# Altium schematic sheet Motor.SchDoc
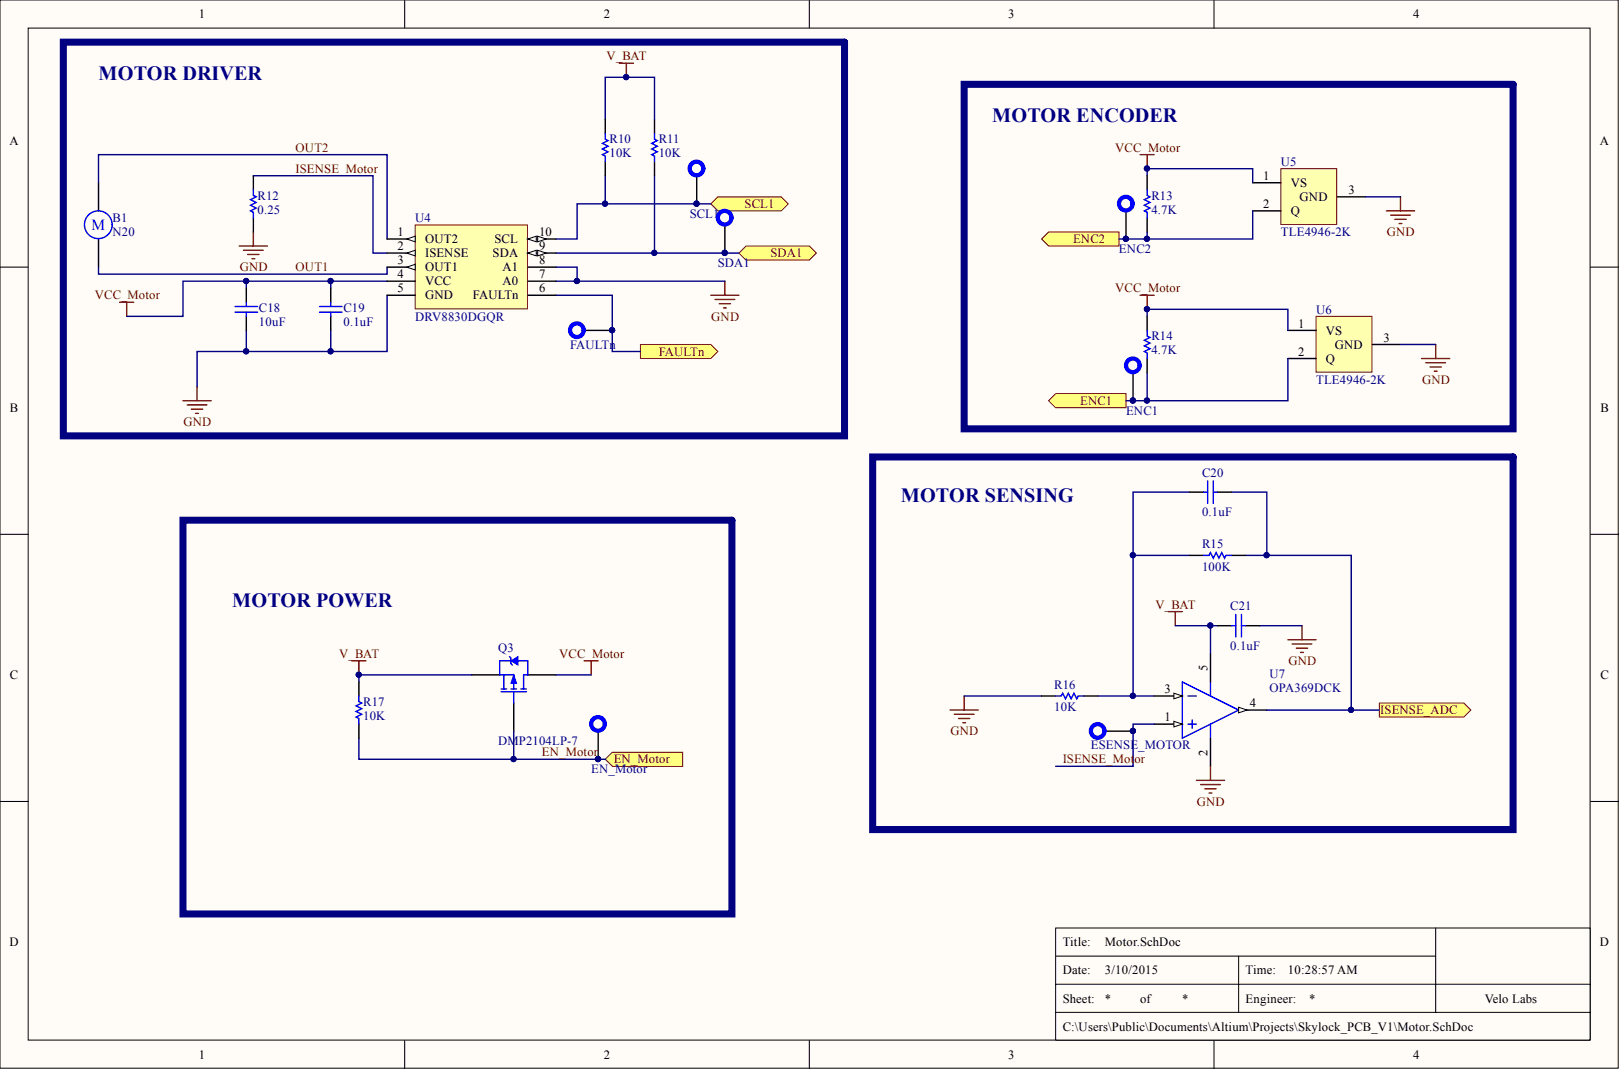
[diagram p0: Motor.SchDoc]

## Parts
B1  N20  [n20_motor]
C18  10uF  [C0603]
C19  0.1uF  [C0402]
C20  0.1uF  [C0402]
C21  0.1uF  [C0402]
EN_Motor  Test Point  [TP]  (value not drawn)  (no BOM)
ENC1  Test Point  [TP]  (value not drawn)  (no BOM)
ENC2  Test Point  [TP]  (value not drawn)  (no BOM)
ESENSE_MOTOR  Test Point  [TP]  (value not drawn)  (no BOM)
FAULTn  Test Point  [TP]  (value not drawn)  (no BOM)
Q3  DMP2104LP-7  [3-dfn]
R10  10K  [R0402]
R11  10K  [R0402]
R12  0.25  [R0805]
R13  4.7K  [R0402]
R14  4.7K  [R0402]
R15  100K  [R0402]
R16  10K  [R0402]
R17  10K  [R0402]
SCL1  Test Point  [TP]  (value not drawn)  (no BOM)
SDA1  Test Point  [TP]  (value not drawn)  (no BOM)
U4  DRV8830DGQR  [QFN50P300X300X80_HS-15N]
U5  TLE4946-2K  [SC59]
U6  TLE4946-2K  [SC59]
U7  OPA369DCK  [SC70-5]

## Ports
EN_MOTOR, ENC1, ENC2, FAULTN, ISENSE_ADC, SCL1, SDA1

## Nets
GND: C18.2 C19.2 C21.2 R12.2 R16.1 U4.0 U4.5(GND) U4.7(A0) U4.8(A1) U5.3 U6.3 U7.2
V_BAT: C21.1 Q3.2 R10.1 R11.1 R17.1 U7.5
VCC_MOTOR: C18.1 C19.1 Q3.3 R13.1 R14.1 U4.4(VCC) U5.1 U6.1
EN_MOTOR: EN_Motor.1 Q3.1 R17.2 ; port EN_MOTOR
ENC1: ENC1.1 R14.2 U6.2 ; port ENC1
ENC2: ENC2.1 R13.2 U5.2 ; port ENC2
ISENSE_ADC: C20.2 R15.2 U7.4 ; port ISENSE_ADC
ISENSE_MOTOR: ESENSE_MOTOR.1 R12.1 U4.2(ISENSE) U7.1
OUT1: B1.2 U4.3(OUT1)
OUT2: B1.1 U4.1(OUT2)
SCL1: R10.2 SCL1.1 U4.10(SCL) ; port SCL1
SDA1: R11.2 SDA1.1 U4.9(SDA) ; port SDA1
NetC20_1: C20.1 R15.1 R16.2 U7.3
NetFAULTn_1: FAULTn.1 U4.6(FAULTn) ; port FAULTN

## Library data of the parts
B1 = Motor: Motor, General Kind; Component Kind: Standard; LatestRevisionDate: 17-Jul-2002; LatestRevisionNote: Re-released for DXP Platform.; PackageDescription: Capacitor (Radial); 2 Leads; PackageReference: RB5-10.5; Published: 24-Mar-1999; Library Name: VL_Skylock_Nordic.SCHLIB; Publisher: Altium Limited
C18 = Cap Semi: Capacitor; Manufacturer: Taiyo Yuden; Manufacturer Part Number: JMK107ABJ106MAHT; Capacitance: 10µF; Temperature Coefficient: X5R; Tolerance: ±20%; Voltage - Rated: 6.3V; Applications: Automotive; Category: Capacitors; Component Kind: Standard; ComponentLink1Description: http://www.yuden.co.jp/productdata/catalog/en/mlcc01_hq_e.pdf; ComponentLink1URL: http://www.yuden.co.jp/productdata/catalog/en/mlcc01_hq_e.pdf; Features: -; Height - Seated (Max): -; LatestRevisionDate: 29-May-2009; LatestRevisionNote: IPC-7351 Footprint Added.; Lead Spacing: -; Lead Style: -; Mounting Type: Surface Mount, MLCC; Operating Temperature: -55°C ~ 85°C; Package / Case: 0603 (1608 Metric); PackageDescription: Chip Capacitor; PackageReference: C1206; Packaging: Cut Tape (CT); Pricing 1: 1=0.32, 10=0.21, 50=0.109, 100=0.084, 250=0.06036, 500=0.04306, 1000=0.0315 (USD); Published: 8-Jun-2000; Ratings: AEC-Q200; RoHS: RoHS Compliant; Signal Integrity: Cap Semi; Simulation: CAP; Size / Dimension: 0.063" L x 0.031" W (1.60mm x 0.80mm); Stock 1: 197603; Supplier 1: Digi-Key; Supplier Part Number 1: 587-3383-1-ND; Thickness (Max): 0.035" (0.90mm); Value: 10uF; Library Name: VL_Skylock_Nordic.SCHLIB; Publisher: Altium Limited
C19-C21 = Cap Semi: Capacitor; Manufacturer: Murata Electronics North America; Manufacturer Part Number: GRM155R61A104KA01D; Capacitance: 0.1µF; Temperature Coefficient: X5R; Tolerance: ±10%; Voltage - Rated: 10V; Applications: General Purpose; Category: Capacitors; Component Kind: Standard; ComponentLink1Description: http://www.murata.com/~/media/webrenewal/support/library/catalog/products/capacitor/mlcc/c02e.ashx; ComponentLink1URL: http://www.murata.com/~/media/webrenewal/support/library/catalog/products/capacitor/mlcc/c02e.ashx; Features: -; Height - Seated (Max): -; LatestRevisionDate: 29-May-2009; LatestRevisionNote: IPC-7351 Footprint Added.; Lead Spacing: -; Lead Style: -; Mounting Type: Surface Mount, MLCC; Operating Temperature: -55°C ~ 85°C; Package / Case: 0402 (1005 Metric); PackageDescription: Chip Capacitor; PackageReference: C1206; Packaging: Cut Tape (CT); Pricing 1: 1=0.1, 10=0.012, 50=0.0066, 100=0.0055, 250=0.00452, 500=0.00386, 1000=0.003, 5000=0.0025 (USD); Published: 8-Jun-2000; Ratings: -; RoHS: RoHS Compliant; Signal Integrity: Cap Semi; Simulation: CAP; Size / Dimension: 0.039" L x 0.020" W (1.00mm x 0.50mm); Stock 1: 7992964; Supplier 1: Digi-Key; Supplier Part Number 1: 490-1318-1-ND; Thickness (Max): 0.022" (0.55mm); Value: 0.1uF; Library Name: VL_Skylock_Nordic.SCHLIB; Publisher: Altium Limited
Q3 = DMP2104LP-7: MOSFET P-CH 20V 1.5A 3-DFN; Manufacturer: Diodes Inc; Current - Continuous Drain (Id) @ 25° C: 1.5A (Ta); FET Feature: Logic Level Gate; FET Type: MOSFET P-Channel, Metal Oxide; Input Capacitance (Ciss) @ Vds: 320pF @ 16V; Power - Max: 500mW; Rds On (Max) @ Id, Vgs: 150 mOhm @ 950mA, 4.5V; Vgs(th) (Max) @ Id: 1V @ 250µA; Category: Discrete Semiconductor Products; Component Kind: Standard; ComponentLink1Description: http://www.diodes.com/datasheets/ds31091.pdf; ComponentLink1URL: http://www.diodes.com/datasheets/ds31091.pdf; Description 1: MOSFET P-CH 20V 1.5A 3-DFN; Drain to Source Voltage (Vdss): 20V; Gate Charge (Qg) @ Vgs: -; LatestRevisionDate: 17-Jul-2002; LatestRevisionNote: Re-released for DXP Platform.; Manufacturer Part Number: DMP2104LP-7; Mounting Type: Surface Mount; Package / Case: 3-XDFN; PackageDescription: TO, Flat Index; 3 In-Line, Axial Leads; Body Dia. 4.6mm; Lead Dia. 0.5 mm (max); PackageReference: E3; PackageVersion: 1995/1996; Packaging: Digi-Reel®; Pricing 1: 1=0.44, 10=0.341, 25=0.288, 100=0.2347, 250=0.19436, 500=0.16056, 1000=0.12025 (USD); Published: 8-Jun-2000; RoHS: RoHS Compliant; Simulation: PMOS; Stock 1: 8333; Supplier 1: Digi-Key; Supplier Device Package: DFN1411-3; Supplier Part Number 1: DMP2104LPDIDKR-ND; Library Name: VL_Skylock_Nordic.SCHLIB; Publisher: Altium Limited
R10 R11 R16 R17 = Res: Resistor; Manufacturer: Panasonic Electronic Components; Manufacturer Part Number: ERJ-2RKF1002X; Power (Watts): 0.1W, 1/10W; Temperature Coefficient: ±100ppm/°C; Tolerance: ±1%; Category: Resistors; Component Kind: Standard; ComponentLink1Description: http://industrial.panasonic.com/www-cgi/jvcr13pz.cgi?E+PZ+3+AOA0002+ERJ2RKF1002X+7+WW; ComponentLink1URL: http://industrial.panasonic.com/www-cgi/jvcr13pz.cgi?E+PZ+3+AOA0002+ERJ2RKF1002X+7+WW; Composition: Thick Film; Features: Automotive AEC-Q200; Height: 0.016" (0.40mm); LatestRevisionDate: 17-Jul-2002; LatestRevisionNote: Re-released for DXP Platform.; Number of Terminations: 2; Operating Temperature: -55°C ~ 155°C; Package / Case: 0402 (1005 Metric); PackageDescription: Axial Device, Thru-Hole; 2 Leads; 0.3 in Pin Spacing; PackageReference: AXIAL-0.3; Packaging: Cut Tape (CT); Pricing 1: 1=0.1, 50=0.0196, 100=0.0149, 250=0.01104, 500=0.00816, 1000=0.00544, 5000=0.00383 (USD); Published: 8-Jun-2000; Resistance (Ohms): 10k; RoHS: RoHS Compliant; Signal Integrity: Res; Simulation: RESISTOR; Size / Dimension: 0.039" L x 0.020" W (1.00mm x 0.50mm); Stock 1: 8361710; Supplier 1: Digi-Key; Supplier Device Package: 0402; Supplier Part Number 1: P10.0KLCT-ND; Value: 10K; Library Name: VL_Skylock_Nordic.SCHLIB; Publisher: Altium Limited
R12 = Res: Resistor; Manufacturer: Stackpole Electronics Inc; Manufacturer Part Number: CSR0805FKR250; Power (Watts): 0.25W, 1/4W; Temperature Coefficient: ±200ppm/°C; Tolerance: ±1%; Category: Resistors; Component Kind: Standard; ComponentLink1Description: http://www.seielect.com/catalog/SEI-CSR_CSRN.pdf; ComponentLink1URL: http://www.seielect.com/catalog/SEI-CSR_CSRN.pdf; Composition: Thick Film; Features: Current Sense; Height: 0.026" (0.65mm); LatestRevisionDate: 17-Jul-2002; LatestRevisionNote: Re-released for DXP Platform.; Number of Terminations: 2; Operating Temperature: -55°C ~ 155°C; Package / Case: 0805 (2012 Metric); PackageDescription: Axial Device, Thru-Hole; 2 Leads; 0.3 in Pin Spacing; PackageReference: AXIAL-0.3; Packaging: Cut Tape (CT); Pricing 1: 1=0.56, 10=0.38, 50=0.23, 100=0.19, 250=0.15, 500=0.128 (USD); Published: 8-Jun-2000; Resistance (Ohms): 0.25; RoHS: RoHS Compliant; Signal Integrity: Res; Simulation: RESISTOR; Size / Dimension: 0.079" L x 0.049" W (2.00mm x 1.25mm); Stock 1: 4846; Supplier 1: Digi-Key; Supplier Device Package: 0805; Supplier Part Number 1: CSR0805FKR250CT-ND; Value: 0.25; Library Name: VL_Skylock_Nordic.SCHLIB; Publisher: Altium Limited
R13 R14 = Res: Resistor; Manufacturer: Panasonic Electronic Components; Manufacturer Part Number: ERJ-2GEJ472X; Power (Watts): 0.1W, 1/10W; Temperature Coefficient: ±200ppm/°C; Tolerance: ±5%; Category: Resistors; Component Kind: Standard; ComponentLink1Description: http://industrial.panasonic.com/www-cgi/jvcr13pz.cgi?E+PZ+3+AOA0001+ERJ2GEJ472X+7+WW; ComponentLink1URL: http://industrial.panasonic.com/www-cgi/jvcr13pz.cgi?E+PZ+3+AOA0001+ERJ2GEJ472X+7+WW; Composition: Thick Film; Features: Automotive AEC-Q200; Height: 0.016" (0.40mm); LatestRevisionDate: 17-Jul-2002; LatestRevisionNote: Re-released for DXP Platform.; Number of Terminations: 2; Operating Temperature: -55°C ~ 155°C; Package / Case: 0402 (1005 Metric); PackageDescription: Axial Device, Thru-Hole; 2 Leads; 0.3 in Pin Spacing; PackageReference: AXIAL-0.3; Packaging: Cut Tape (CT); Pricing 1: 1=0.1, 50=0.0138, 100=0.0105, 250=0.0078, 500=0.00576, 1000=0.00384, 5000=0.0027 (USD); Published: 8-Jun-2000; Resistance (Ohms): 4.7k; RoHS: RoHS Compliant; Signal Integrity: Res; Simulation: RESISTOR; Size / Dimension: 0.039" L x 0.020" W (1.00mm x 0.50mm); Stock 1: 3738322; Supplier 1: Digi-Key; Supplier Device Package: 0402; Supplier Part Number 1: P4.7KJCT-ND; Value: 4.7K; Library Name: VL_Skylock_Nordic.SCHLIB; Publisher: Altium Limited
R15 = Res: Resistor; Manufacturer: Panasonic Electronic Components; Manufacturer Part Number: ERJ-2GEJ104X; Power (Watts): 0.1W, 1/10W; Temperature Coefficient: ±200ppm/°C; Tolerance: ±5%; Category: Resistors; Component Kind: Standard; ComponentLink1Description: http://industrial.panasonic.com/www-cgi/jvcr13pz.cgi?E+PZ+3+AOA0001+ERJ2GEJ104X+7+WW; ComponentLink1URL: http://industrial.panasonic.com/www-cgi/jvcr13pz.cgi?E+PZ+3+AOA0001+ERJ2GEJ104X+7+WW; Composition: Thick Film; Features: Automotive AEC-Q200; Height: 0.016" (0.40mm); LatestRevisionDate: 17-Jul-2002; LatestRevisionNote: Re-released for DXP Platform.; Number of Terminations: 2; Operating Temperature: -55°C ~ 155°C; Package / Case: 0402 (1005 Metric); PackageDescription: Axial Device, Thru-Hole; 2 Leads; 0.3 in Pin Spacing; PackageReference: AXIAL-0.3; Packaging: Cut Tape (CT); Pricing 1: 1=0.1, 50=0.0138, 100=0.0105, 250=0.0078, 500=0.00576, 1000=0.00384, 5000=0.0027 (USD); Published: 8-Jun-2000; Resistance (Ohms): 100k; RoHS: RoHS Compliant; Signal Integrity: Res; Simulation: RESISTOR; Size / Dimension: 0.039" L x 0.020" W (1.00mm x 0.50mm); Stock 1: 1549135; Supplier 1: Digi-Key; Supplier Device Package: 0402; Supplier Part Number 1: P100KJCT-ND; Value: 100K; Library Name: VL_Skylock_Nordic.SCHLIB; Publisher: Altium Limited
U4 = DRV8830DGQR: IC MOTOR DRIVER I2C 10MSOP; Manufacturer: Texas Instruments; Current - Output: 1A; Function: Driver - Fully Integrated, Control and Power Stage; Motor Type - AC, DC: Brushed DC, PMSM; Motor Type - Stepper: Bipolar; Output Configuration: Full H-Bridge, (1) Single; Voltage - Load: 2.75 V ~ 6.8 V; Applications: Battery Powered; Category: Integrated Circuits (ICs); Component Kind: Standard; ComponentLink1Description: http://www.ti.com/lit/ds/symlink/drv8830.pdf; ComponentLink1URL: http://www.ti.com/lit/ds/symlink/drv8830.pdf; Interface: I2C; Manufacturer Part Number: DRV8830DGQR; Mounting Type: Surface Mount; Operating Temperature: -40°C ~ 85°C; Package / Case: 10-VFDFN Exposed Pad; Packaging: Cut Tape (CT); Pricing 1: 1=2.44, 10=2.203, 25=1.9736, 100=1.7748, 250=1.57592, 500=1.377, 750=1.24695, 1000=0.95625 (USD); RoHS: RoHS Compliant; Stock 1: 1948; Supplier 1: Digi-Key; Supplier Device Package: 10-VSON (3x3); Supplier Part Number 1: 296-30544-1-ND; Voltage - Supply: 2.75 V ~ 6.8 V; Library Name: VL_Skylock_Nordic.SCHLIB
U5 U6 = TLE4946-2K: Hall Effect switch; Manufacturer: Infineon Technologies; Current - Output (Max): 20mA; Current - Supply: 4mA; Description 1: IC HALL EFFECT LATCH SC59; Output Type: Digital, Open Collector; Sensing Range: 2mT Trip, -2mT Release; Voltage - Supply: 2.7 V ~ 18 V; Category: Sensors, Transducers; Component Kind: Standard; ComponentLink1Description: http://www.infineon.com/dgdl/TLE4946-2K_DS_Rev1.0.pdf?folderId=db3a30431f848401011facc1c83b4674&fileId=db3a30431f848401011fbc925c48637f; ComponentLink1URL: http://www.infineon.com/dgdl/TLE4946-2K_DS_Rev1.0.pdf?folderId=db3a30431f848401011facc1c83b4674&fileId=db3a30431f848401011fbc925c48637f; Features: Regulated Voltage; Manufacturer Part Number: TLE4946-2K; Operating Temperature: -40°C ~ 150°C; Package / Case: TO-236-3, SC-59, SOT-23-3; Packaging: Cut Tape (CT); Pricing 1: 1=1.1, 5=1.042, 10=0.908, 25=0.7976, 50=0.6624, 100=0.5643, 250=0.51528, 500=0.49074, 1000=0.42939 (USD); RoHS: RoHS Compliant; Stock 1: 7162; Supplier 1: Digi-Key; Supplier Device Package: SC-59; Supplier Part Number 1: TLE4946-2KCT-ND; Type: Bipolar Latch; Library Name: VL_Skylock_Nordic.SCHLIB
U7 = OPA369DCK: Ultra low power single supply op amp; Manufacturer: Texas Instruments; Manufacturer Part Number: OPA369AIDCKR; Amplifier Type: General Purpose; Current - Input Bias: 10pA; Current - Output / Channel: 10mA; Current - Supply: 0.8µA; Description 1: IC OPAMP RRIO 1.8V SGL SC70-5; Gain Bandwidth Product: 12kHz; Number of Circuits: 1; Output Type: Rail-to-Rail; Slew Rate: 0.005 V/µs; Voltage - Input Offset: 250µV; Voltage - Supply, Single/Dual (±): 1.8 V ~ 5.5 V; -3db Bandwidth: -; Category: Integrated Circuits (ICs); Component Kind: Standard; ComponentLink1Description: http://www.ti.com/lit/ds/symlink/opa369.pdf; ComponentLink1URL: http://www.ti.com/lit/ds/symlink/opa369.pdf; Mounting Type: Surface Mount; Operating Temperature: -40°C ~ 85°C; Package / Case: 6-TSSOP (5 Lead), SC-88A, SOT-353; Packaging: Cut Tape (CT); Pricing 1: 1=1.87, 10=1.685, 25=1.5092, 100=0.7898, 250=0.77512, 500=0.7605, 750=0.74588 (USD); RoHS: RoHS Compliant; Simulation: OPA369; Stock 1: 10239; Supplier 1: Digi-Key; Supplier Device Package: SC-70-5; Supplier Part Number 1: 296-25252-1-ND; Library Name: VL_Skylock_Nordic.SCHLIB
EN_Motor ENC1 ENC2 ESENSE_MOTOR FAULTn SCL1 SDA1 = Test Point: Description: Test Point; Library Name: VL_Skylock_Nordic.SCHLIB
